# Altium schematic sheet ESP32.SchDoc
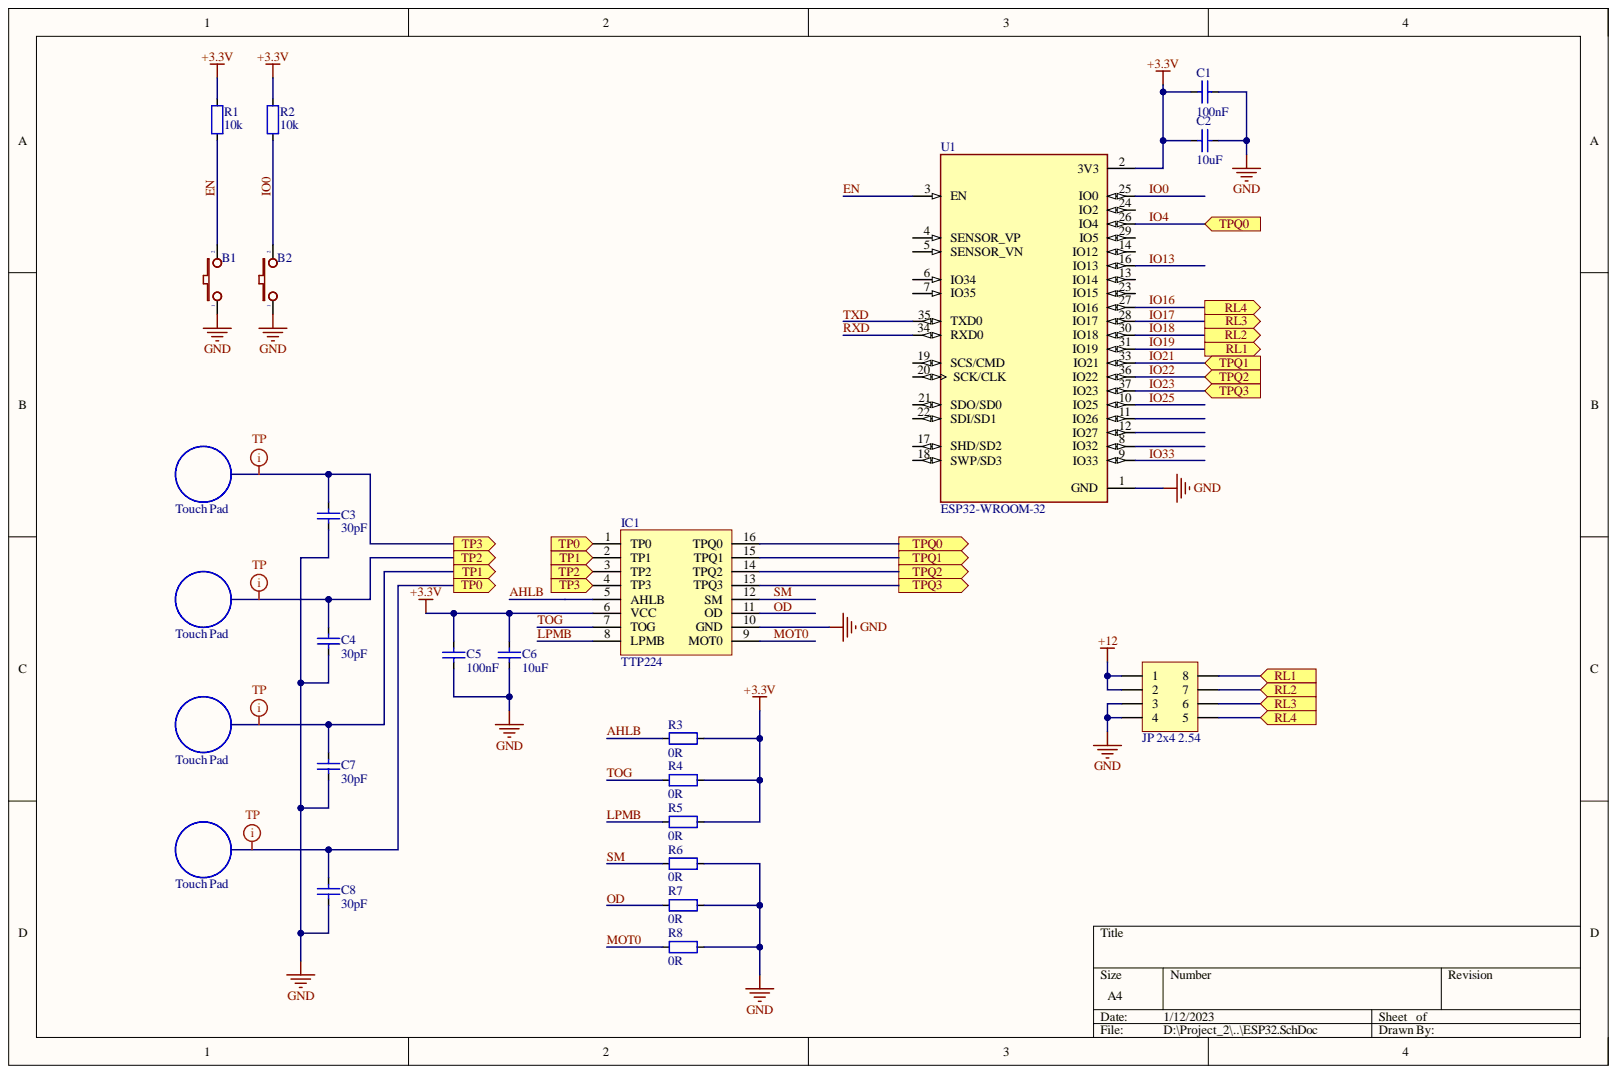
[diagram p0: ESP32.SchDoc]

## Parts
B1  Button 3x4x2.5  [Button 3x4x2.5]  (value not drawn)
B2  Button 3x4x2.5  [Button 3x4x2.5]  (value not drawn)
C1  100nF  [CAP_0805]
C2  10uF  [CAP_0805]
C3  30pF  [CAP_0805]
C4  30pF  [CAP_0805]
C5  100nF  [CAP_0805]
C6  10uF  [CAP_0805]
C7  30pF  [CAP_0805]
C8  30pF  [CAP_0805]
IC1  TTP224  [TTP224]
JP1  JP 2x4 2.54  [JP2x4_PAD]
R1  10k  [RES-SMD-0603]
R2  10k  [RES-SMD-0603]
R3  0R  [RES-SMD-0603]
R4  0R  [RES-SMD-0603]
R5  0R  [RES-SMD-0603]
R6  0R  [RES-SMD-0603]
R7  0R  [RES-SMD-0603]
R8  0R  [RES-SMD-0603]
U1  ESP32-WROOM-32  [MODULE_ESP32-WROOM-32]

## Ports
RL1, RL2, RL3, RL4, TP0, TP1, TP2, TP3, TPQ0, TPQ1, TPQ2, TPQ3

## Nets
+3.3V: C1.1 C2.1 C5.2 C6.2 IC1.6(VCC) R1.1 R2.1 R3.2 R4.2 R5.2 U1.2(3V3)
+12: JP1.1 JP1.2
GND: B1.1 B2.1 C1.2 C2.2 C3.1 C4.1 C5.1 C6.1 C7.1 C8.1 IC1.10(GND) JP1.3 JP1.4 R6.2 R7.2 R8.2 U1.1(GND)
AHLB: IC1.5(AHLB) R3.1
EN: B1.2 R1.2 U1.3(EN)
IO0: B2.2 R2.2 U1.25(IO0)
IO4: IC1.16(TPQ0) U1.26(IO4) ; port TPQ0
IO13: U1.16(IO13)
IO16: JP1.5 U1.27(IO16) ; port RL4
IO17: JP1.6 U1.28(IO17) ; port RL3
IO18: JP1.7 U1.30(IO18) ; port RL2
IO19: JP1.8 U1.31(IO19) ; port RL1
IO21: IC1.15(TPQ1) U1.33(IO21) ; port TPQ1
IO22: IC1.14(TPQ2) U1.36(IO22) ; port TPQ2
IO23: IC1.13(TPQ3) U1.37(IO23) ; port TPQ3
IO25: U1.10(IO25)
IO33: U1.9(IO33)
LPMB: IC1.8(LPMB) R5.1
MOT0: IC1.9(MOT0) R8.1
OD: IC1.11(OD) R7.1
RXD: U1.34(RXD0)
SM: IC1.12(SM) R6.1
TOG: IC1.7(TOG) R4.1
TP0: C8.2 IC1.1(TP0) ; port TP0
TP1: C7.2 IC1.2(TP1) ; port TP1
TP2: C4.2 IC1.3(TP2) ; port TP2
TP3: C3.2 IC1.4(TP3) ; port TP3
TXD: U1.35(TXD0)

Not connected: U1 4(SENSOR_VP) 5(SENSOR_VN) 6(IO34) 7(IO35) 8(IO32) 11(IO26) 12(IO27) 13(IO14) 14(IO12) 17(SHD/SD2) 18(SWP/SD3) 19(SCS/CMD) 20(SCK/CLK) 21(SDO/SD0) 22(SDI/SD1) 23(IO15) 24(IO2) 29(IO5)

## Library data of the parts
C1-C8 = CapSMD: Capacitor; Value: 33p; Component Kind: Standard; LatestRevisionDate: 17-Jul-2002; LatestRevisionNote: Re-released for DXP Platform.; Library Name: My Library.SchLib; PackageReference: RAD-0.3; Published: 8-Jun-2000; Publisher: Altium Limited
JP1 = JP 2x4 2.54 Duc Thang: Cắm; Don_Vi: Con; Ma_Hang: MH002686; Ten_Linh_Kien: Jump Đôi Đực 2.54MM 2x40P Thẳng 11MM; Chung_Loai: Cắm; Component Kind: Standard; Library Name: Altium_Lib_by_KhueNguyenCreator.IntLib
R1-R8 = Resistor - SMD: Resistor; Manufacturer: Generic Components; Class: Passive; Component Kind: Standard; Library Name: My Library.SchLib; Published: 08/06/2000; Publisher: Altium Hobart Technology Centre
U1 = ESP32-WROOM-32: Bluetooth, WiFi Transceiver Module 2.4GHz ~ 2.5GHz Surface Mount; MF: Espressif Systems; Component Kind: Standard; Digi-Key_Part_Number: 1904-1010-1-ND; Digi-Key_Purchase_URL: https://www.digikey.com/product-detail/en/espressif-systems/ESP32-WROOM-32/1904-1010-1-ND/8544305?utm_source=snapeda&utm_medium=aggregator&utm_campaign=symbol; Library Name: My Library.SchLib; MP: ESP32-WROOM-32; Package: Module Espressif Systems; SnapEDA_Link: https://www.snapeda.com/parts/ESP32-WROOM-32/Espressif%20Systems/view-part/2753322/?ref=snap
B1 B2 = Button 3x4x2.5: Component Kind: Standard; Library Name: My Library.SchLib
IC1 = TTP224: Component Kind: Standard; Library Name: My Library.SchLib
